# Altium schematic sheet Controll Circuitry.SchDoc
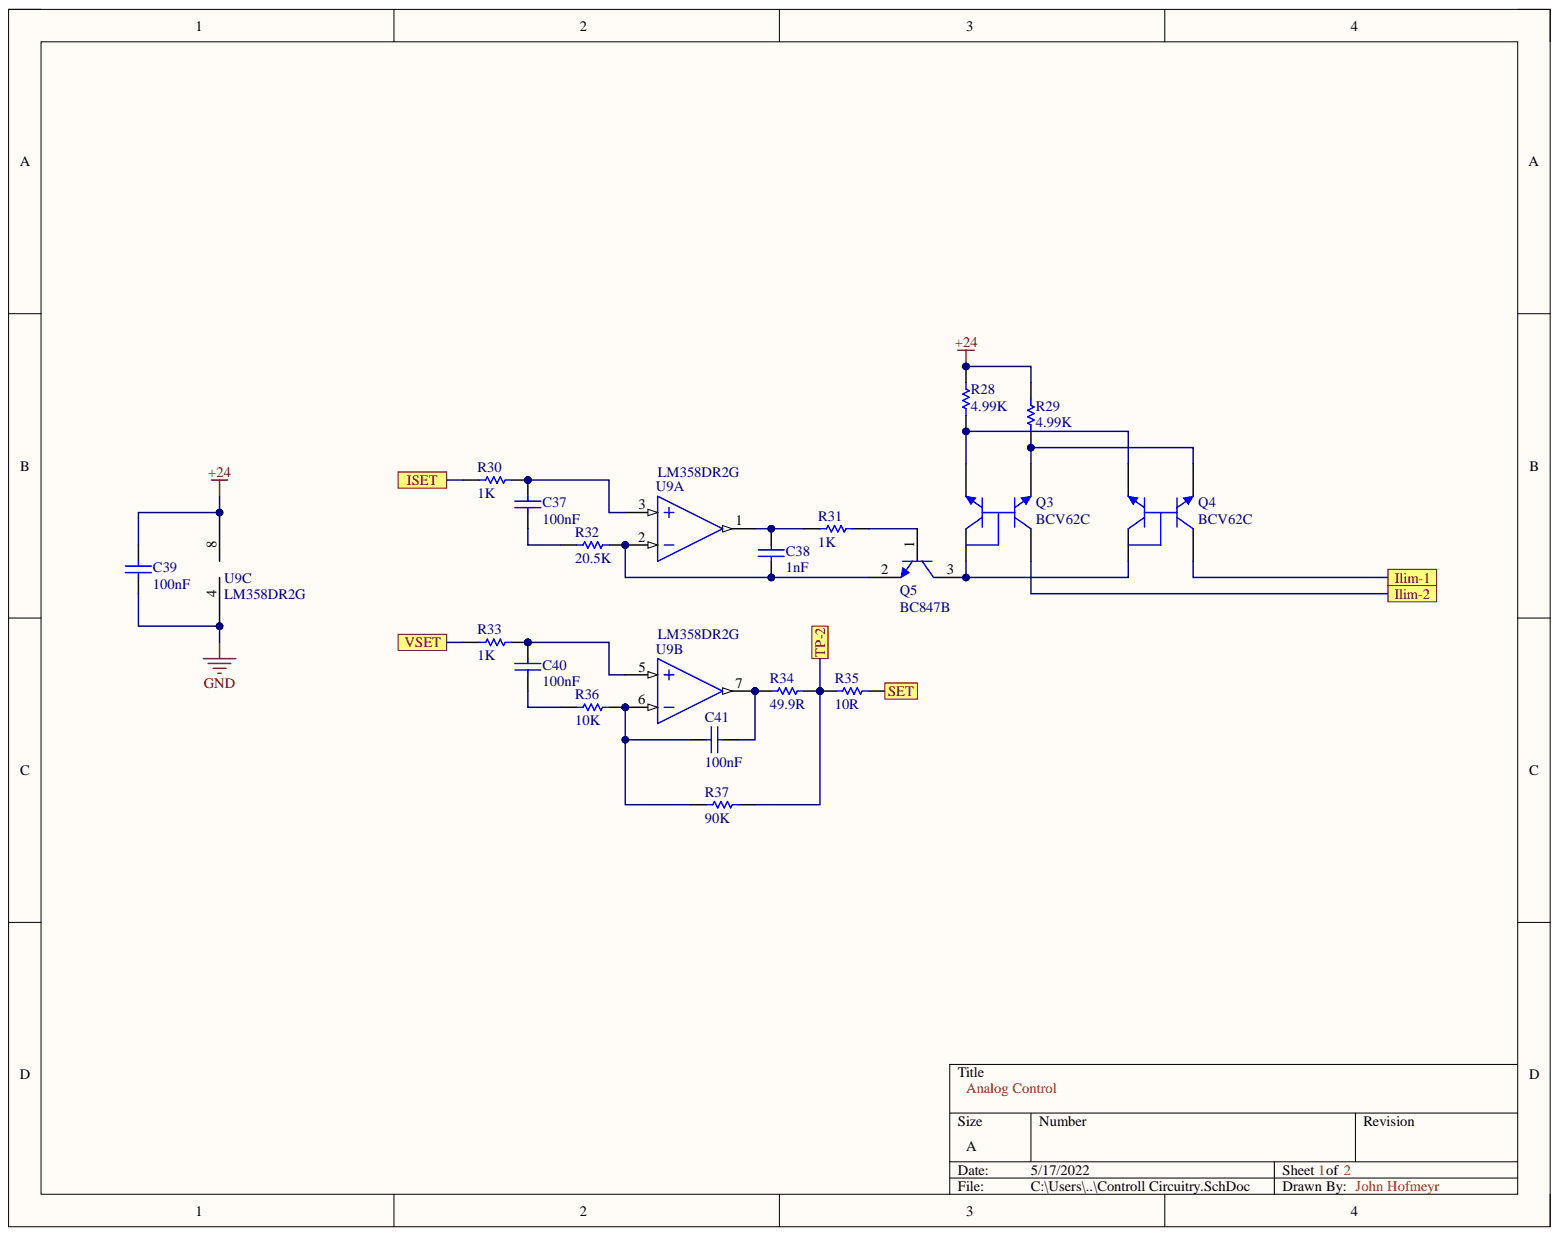
[diagram p0: Controll Circuitry.SchDoc]

## Parts
C37  100nF  [MURA-0805_H_1.35_M]
C38  1nF  [MURA-0805_H_1.35_M]
C39  100nF  [MURA-0805_H_1.35_M]
C40  100nF  [MURA-0805_H_1.35_M]
C41  100nF  [MURA-0805_H_1.35_M]
Q3  BCV62C  [INF-SOT143-4-1_V]
Q4  BCV62C  [INF-SOT143-4-1_V]
Q5  BC847B  [INF-SOT23_M]
R28  4.99K  [RESC2013X60X40LL20T25]
R29  4.99K  [RESC2013X60X40LL20T25]
R30  1K  [RESC2013X60X40LL20T25]
R31  1K  [RESC2013X60X40LL20T25]
R32  20.5K  [RESC2013X60X40LL20T25]
R33  1K  [RESC2013X60X40LL20T25]
R34  49.9R  [RESC2013X60X40LL20T25]
R35  10R  [RESC2013X60X40LL20T25]
R36  10K  [RESC2013X60X40LL20T25]
R37  90K  [RESC2013X60X40LL20T25]
U9  LM358DR2G  [ONSC-SOIC-8-751-07_V]  (parts A,B,C)

## Ports
Ilim-1, Ilim-2, ISET, SET, TP-2, VSET

## Nets
+24: C39.1 R28.1 R29.1 U9.8
GND: C39.2 U9.4
Ilim-1: Q4.2 ; port Ilim-1
Ilim-2: Q3.2 ; port Ilim-2
ISET: R30.1 ; port ISET
SET: R35.2 ; port SET
TP-2: R34.2 R35.1 R37.2 ; port TP-2
VSET: R33.1 ; port VSET
NetC37_1: C37.1 R32.1
NetC37_2: C37.2 R30.2 U9.3
NetC38_1: C38.1 Q5.2 R32.2 U9.2
NetC38_2: C38.2 R31.1 U9.1
NetC40_1: C40.1 R36.1
NetC40_2: C40.2 R33.2 U9.5
NetC41_1: C41.1 R34.1 U9.7
NetC41_2: C41.2 R36.2 R37.1 U9.6
NetQ3_1: Q3.1 Q4.1 Q5.3
NetQ3_3: Q3.3 Q4.3 R29.2
NetQ3_4: Q3.4 Q4.4 R28.2
NetQ5_1: Q5.1 R31.2

## Library data of the parts
C37-C41 = CMP-2000-05254-1: CAP, Ceramic, 10 uF, 10%, 10 V, 0805, RoHS, Tape and Reel; Manufacturer: Murata; Capacitance: 10µF; Catalog Drawings: GRMSeries_0805_1.25; Featured Product: GA3SafetyRecognizedCapsandHi-CapMLCC; Online Catalog: GRMSeries; Other Names: 490-1709-6; Product Training Modules: High-CapMultilayerCeramicCapacitors; Temperature Coefficient: X5R; Voltage - Rated: 10V; Applications: GeneralPurpose; Category: Capacitors; Component Kind: Standard; Datasheet URL: https://datasheet.ciiva.com/3777/210grm21br61a106ke1923-3777175.pdf; Datasheet Version: Aug-14; Family: CeramicCapacitors; Features: -; Height - Seated (Max): -; Lead Spacing: -; Lead Style: -; Library Name: Altium Content Vault; Manufacturer URL: http://www.murata.com/; Mounting Type: SurfaceMount,MLCC; Operating Temperature: -55degC~85degC; Package / Case: 0805(2012Metric); Package Description: 2-Pin Surface Mount Device (0805), Body 2.1 x 1.35 mm; Package Reference: 805; Packaging: Digi-Reel; Ratings: -; Series: GRM; Size / Dimension: 0.079"Lx0.049"W(2.00mmx1.25mm); Standard Package: 1; Thickness (Max): 0.053"(1.35mm); Tolerance: ±10%
Q3 Q4 = CMP-1190-00004-1: PNP Silicon Double Transistor, 30 V VCEO, 100 mA IC, SOT143-4-1, Reel, Green; Manufacturer: Infineon; fT (typ): 250Â MHz; Green: TRUE; IC: 100 mA; ICBO (max): 15Â nA; ICM (max): 200Â mA; Ptot (max): 300 mV; VCBO (max): 30Â V; VCE: 5Â V; VEBO (max): 6Â V; Component Kind: Standard; ComponentLink1Description: Manufacturer URL; ComponentLink1URL: http://www.infineon.com/; ComponentLink2Description: Datasheet; ComponentLink2URL: http://www.infineon.com/dgdl/bcv62.pdf?folderId=db3a30431441fb5d0114498f7eab01ce&fileId=db3a30431441fb5d011449a5795f0230; ComponentLink3Description: Package Specification; ComponentLink3URL: http://www.infineon.com/dgdl/SOT143-Package_Overview.pdf?folderId=db3a30431936bc4b011952ce8b1b3bed&fileId=db3a30431936bc4b011952df42203c0c; IC (max): 100 mA; Library Name: Altium Content Vault; Mounting Technology: Surface Mount; PackageDescription: 4-Pin Small Outline Transistor Package, 1.3 x 2.9 mm Body; PackageReference: SOT143-4-1; Packing: Reel; PartNumber: BCV62C; Polarity: PNP; VCE (max): 5Â V; VCEO (max): 30Â V
Q5 = CMP-1177-00042-1: NPN Silicon AF Transistor, 45 V VCEO, 100 mA IC, SOT23, Reel, Green; Manufacturer: Infineon; fT (typ): 250Â MHz; Green: TRUE; IBM (max): 200Â mA; IC (max): 100Â mA; ICBO (max): 15Â nA; Ptot (max): 330Â mW; VCBO (max): 50Â V; VCEO (max): 45Â V; VEBO (max): 6Â V; Component Kind: Standard; ComponentLink1Description: Manufacturer URL; ComponentLink1URL: http://www.infineon.com/; ComponentLink2Description: Datasheet; ComponentLink2URL: http://www.infineon.com/dgdl/bc847series_bc848series_bc849series_bc850series.pdf?folderId=db3a304314dca389011541d30fa21656&fileId=db3a304314dca389011541d4630a1657; ComponentLink3Description: Package Specification; ComponentLink3URL: http://www.infineon.com/dgdl/SOT23-Package_Overview.pdf?folderId=db3a30431936bc4b0119532290053c66&fileId=db3a30431936bc4b0119533468123c85; ICM (max): 200Â mA; Library Name: Altium Content Vault; Mounting Technology: Surface Mount; PackageDescription: 3-Pin Small Outline Transistor Package, 1.3 x 2.9 mm Body, 0.95 mm Pitch; PackageReference: SOT23; PackageVersion: Apr-08; Packing: Reel; PartNumber: BC847B; Polarity: NPN
R28-R37 = CMP-2001-00654-1: Manufacturer: Panasonic; Part Number: ERA6AEB563V; Component Kind: Standard; Library Name: Altium Content Vault
U9 = CMP-1310-00291-1: Single Supply Dual Operational Amplifier, 3 to 32 V, 0 to 70 degC, 8-Pin SOIC, Pb-Free, Tape and Reel; Manufacturer: On Semiconductor; VCC Max (V): 32; VCC Min (V): 3; Component Kind: Standard; ComponentLink1Description: Manufacturer URL; ComponentLink1URL: http://www.onsemi.com/; ComponentLink2Description: Datasheet; ComponentLink2URL: http://www.onsemi.cn/pub_link/Collateral/LM358-D.PDF; ComponentLink3Description: Package Specification; ComponentLink3URL: http://www.onsemi.com/pub_link/Collateral/751-07.PDF; DatasheetVersion: Rev. 27; Library Name: Components - 07.12.19 - 19.51.IntLib; Mounting Technology: Surface Mount; PackageDescription: 8-Pin Small Outline Integrated Circuit, Body 4.9 x 3.9 mm, Pitch 1.27 mm; PackageReference: SOIC-8-751-07; PackageVersion: Rev. AK, 02/2011; Packing: Tape and Reel; PartNumber: LM358DR2G; RoHS: TRUE
